# Altium schematic sheet PCIe_USB30_Power.SchDoc(PCIe_USB30_Power)
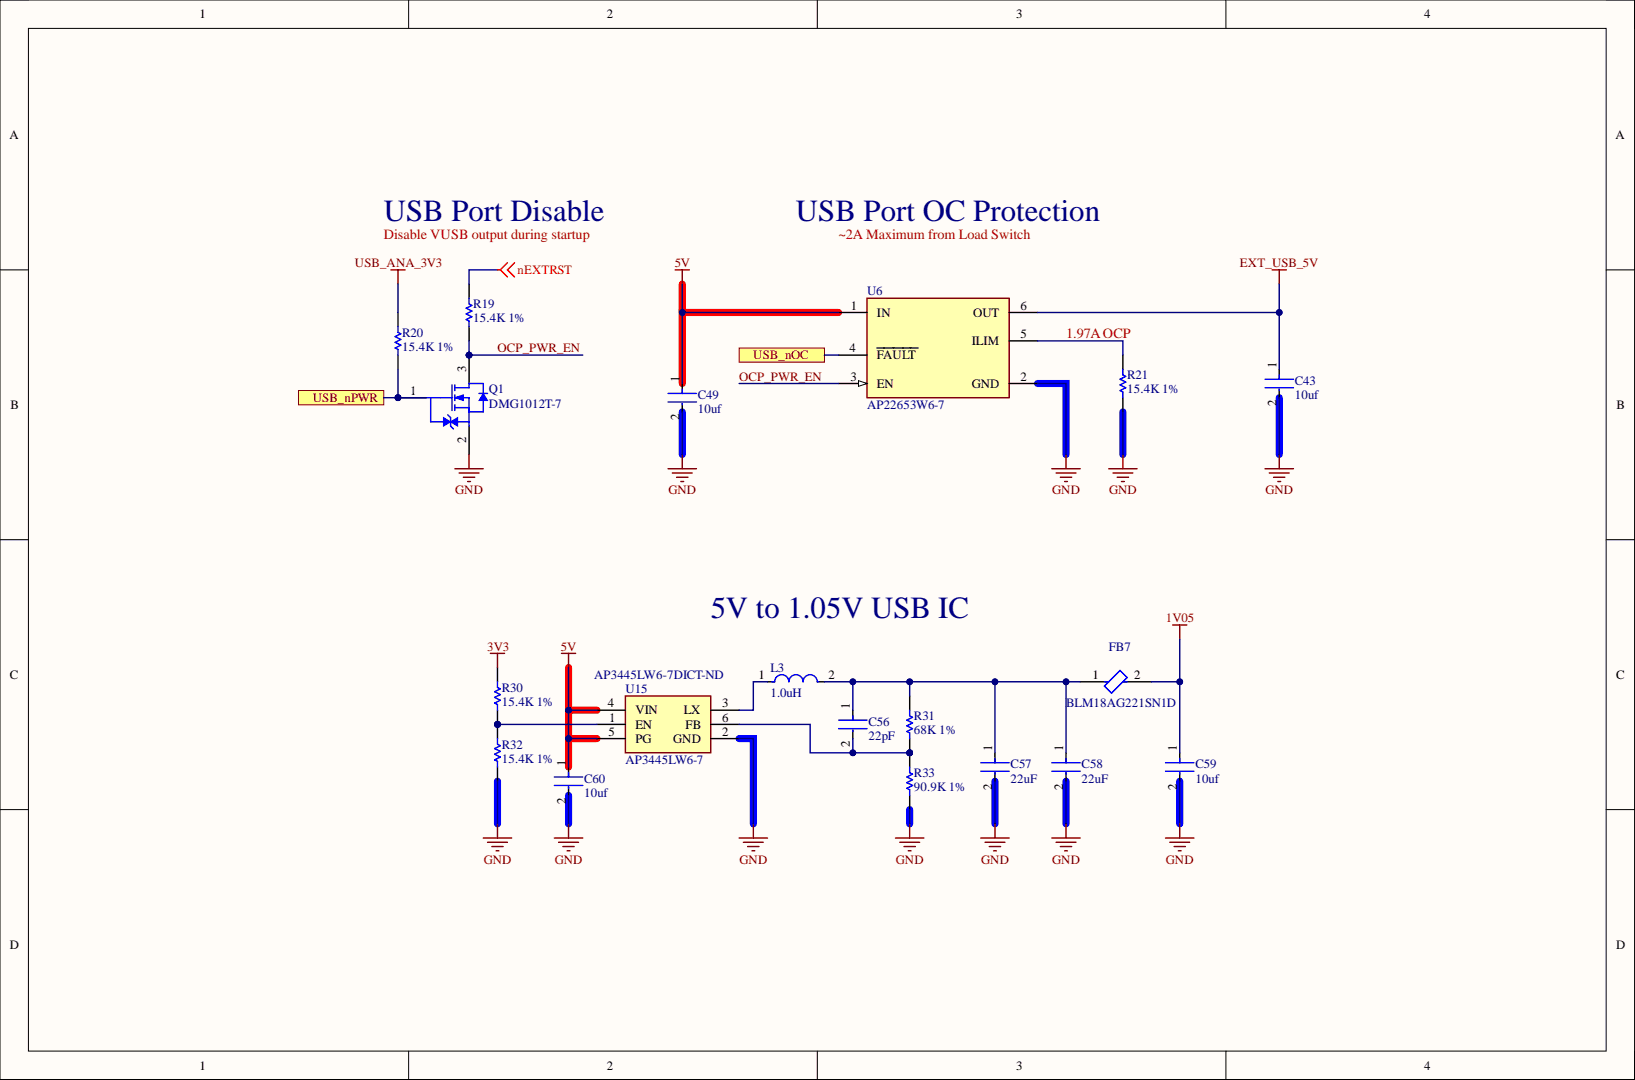
[diagram p0: PCIe_USB30_Power.SchDoc]

## Parts
C43  10uf  [FP-GRM188-0_2-IPC_C]
C49  10uf  [FP-GRM188-0_2-IPC_C]
C56  22pF  [FP-C0603C-CF-MFG]
C57  22uF  [FP-GRM21B-0_15-MFG]
C58  22uF  [FP-GRM21B-0_15-MFG]
C59  10uf  [FP-GRM188-0_2-IPC_C]
C60  10uf  [FP-GRM188-0_2-IPC_C]
FB7  BLM18AG221SN1D  [FP-BLM18-0_15-t0_8-IPC_A]
L3  1.0uH  [FP-NR4018-MFG]
Q1  DMG1012T-7  [DIOD-SOT523-3_V]
R19  15.4K 1%  [RESC1005X40X25ML05T10]
R20  15.4K 1%  [RESC1005X40X25ML05T10]
R21  15.4K 1%  [RESC1005X40X25ML05T10]
R30  15.4K 1%  [RESC1005X40X25ML05T10]
R31  68K 1%  [RESC1005X40X25ML05T05]
R32  15.4K 1%  [RESC1005X40X25ML05T10]
R33  90.9K 1%  [RESC1005X40X25NL05T10]
U6  AP22653W6-7  [FP-SOT26-MFG]
U15  AP3445LW6-7  Supplier Part Number 1: AP3445LW6-7DICT-ND  [SOT95P285X140-6N]

## Ports
USB_nOC, USB_nPWR

## Nets
1V05: C59.1 FB7.2
3V3: R30.2
5V: C49.1 C60.1 U6.1(IN) U15.4(VIN) U15.5(PG)
EXT_USB_5V: C43.1 U6.6(OUT)
GND: C43.2 C49.2 C57.2 C58.2 C59.2 C60.2 Q1.2 R21.1 R32.1 R33.1 U6.2(GND) U15.2(GND)
nEXTRST: R19.2
USB_ANA_3V3: R20.2
OCP_PWR_EN: Q1.3 R19.1 U6.3(EN)
USB_nOC: U6.4(FAULT) ; port USB_nOC
USB_nPWR: Q1.1 R20.1 ; port USB_nPWR
NetC56_1: C56.1 C57.1 C58.1 FB7.1 L3.2 R31.1
NetC56_2: C56.2 R31.2 R33.2 U15.6(FB)
NetL3_1: L3.1 U15.3(LX)
NetR21_2: R21.2 U6.5(ILIM)
NetR30_1: R30.1 R32.2 U15.1(EN)

## Library data of the parts
C43 C49 C59 C60 = CMP-06035-045466-1: Chip Multilayer Ceramic Capacitors for General Purpose, 0603, 10uF, X5R, 15%, 20%, 35V; Capacitance: 10uF; Case Code (Imperial): 0603; Case Code (Metric): 1608; Case/Package: 0603; Component Kind: Standard; Dielectric: X5R; Length: 0.063inch; Library Name: Altium Content Vault; Max Operating Temperature: 85°C; Min Operating Temperature: -55°C; Mount: Surface Mount; Packaging: Tape and Reel; Thickness: 0.039inch; Tolerance: 20%; Voltage Rating: 35V; Voltage Rating (DC): 35V; Width: 0.031inch
C56 = CMP-1035-00818-3: CAP CER 22PF 50V NP0 0603; Manufacturer: KEMET; Manufacturer Part Number: C0603C220D5GACTU; Automotive Grade: Grade 1; Material: Ceramic; Temperature Characteristic: C0G; Temperature Coefficient: 30ppm/°C; Tolerance: 0.5 pF; Automotive: Yes; Capacitance: 22 pF; Category: Cap; Component Kind: Standard; ComponentLink2Description: Datasheet; ComponentLink2URL: https://api.kemet.com/component-edge/download/datasheet/C0603C100J5GACAUTO.pdf; Device Class L1: Passive Components; Device Class L2: Capacitors; Device Class L3: Ceramic Capacitors; Digikey Description: CAP CER 22PF 50V NP0 0603; Height: 0.87mm; Lead Free: Yes; Library Name: Altium Content Vault; Mouser Description: Multilayer Ceramic Capacitors MLCC - SMD/SMT 50volts 22pF C0G 0.5%; Octopart: https://octopart.com/c0603c220d5gactu-kemet-9143734; Package: C0603C-CF; RoHS: TRUE; Temperature: -55°C to +125°C; Temperature Range High: +125°C; Temperature Range Low: -55°C; Value: 22 pF; Voltage Rating: 50 V
C57 C58 = CMP-2007-03385-2: Chip Multilayer Ceramic Capacitors for General Purpose, 0805, 22uF, X5R, 15%, 20%, 6.3V; Capacitance: 22uF; Case Code (Imperial): 0805; Case Code (Metric): 2012; Case/Package: 0805; Component Kind: Standard; Depth: 1.25mm; Dielectric: X5R; Height: 1.25mm; Lead Free: Lead Free; Length: 2mm; Library Name: Altium Content Vault; Material: Ceramic; Max Operating Temperature: 85°C; Min Operating Temperature: -55°C; Mount: Surface Mount; Package Quantity: 1; Packaging: Tape and Reel; Radiation Hardening: No; REACH SVHC: No SVHC; Termination: SMD/SMT; Thickness: 0.053inch; Tolerance: 20%; Voltage: 6.3V; Voltage Rating: 6.3V; Voltage Rating (DC): 6.3V; Width: 0.049inch
FB7 = CMP-0686-00445-3: Chip Ferrite Bead, 0603, 220Ω @ 100MHz, 0.25Ω, 25%, 700mA; Current Rating: 500mA; DC Resistance (DCR): 250mR; Impedance: 220R; Material: Steel; Number of Circuits: 1; Shielding: Unshielded; Case Code (Imperial): 0603; Case Code (Metric): 1608; Case/Package: 0603; Component Kind: Standard; Core Material: Ferrite; DC Current: 500mA; DC Resistance-Max: 250mR; Depth: 0.8mm; Frequency: 100MHz; Height: 0.8mm; Lead Free: Lead Free; Length: 1.6mm; Library Name: Altium Content Vault; Max Current Rating: 500mA; Max DC Current: 500mA; Max Operating Temperature: 125°C; Military Standard: Not; Min Operating Temperature: -55°C; Number of Lines: 1; Number of Pins: 2; Package Quantity: 1; Packaging: Tape and Reel; Radiation Hardening: No; REACH SVHC: No SVHC; RoHS Compliant: Yes; Series: BLM18A; Termination: SMD/SMT; Test Frequency: 100MHz; Tolerance: 25%; Width: 0.8mm
L3 = CMP-14484-000491-1: SMD Power Inductors for Automotive Applications 1.0uH ±30% 3.2A 32.4mΩ; Core Material: Ferrite; DC Resistance (DCR): 32.4mR; Inductance: 1uH; Saturation Current: 4A; Self Resonant Frequency: 90MHz; Shielding: Shielded; Test Frequency: 100kHz; Case/Package: Nonstandard; Component Kind: Standard; Current Rating: 3.2A; Halogen Free: Halogen Free; Height: 1.8mm; Height - Seated (Max): 0.071inch; Length: 4mm; Library Name: Altium Content Vault; Max DC Current: 3.2A; Max Operating Temperature: 125°C; Min Operating Temperature: -40°C; Mount: Surface Mount; Package Quantity: 3500; Packaging: Tape and Reel; Ratings: AEC-Q200; Resistor Type: Wirewound; RoHS Compliant: Yes; Termination: SMD/SMT; Tolerance: 30%; Weight: 0.003527oz; Width: 0.157inch
Q1 = CMP-2000-07450-1: N-Channel Enhancement Mode MOSFET, 20 V, 630 mA, -55 to 150 degC, 3-Pin SOT23, RoHS, Tape and Reel; Manufacturer: Diodes Inc; Case\/Package: SOT-523; Continuous Drain Current (ID): 630mA; Drain to Source Breakdown Voltage: 20V; Drain to Source Resistance: 300mOhm; Element Configuration: Single; Fall Time: 12.3ns; Gate to Source Voltage (Vgs): 6V; Input Capacitance: 60.67pF; Max Power Dissipation: 280mW; Number of Channels: 1; On-State Resistance: 400MOhm; Rise Time: 7.4ns; Threshold Voltage: 1V; Turn-Off Delay Time: 26.7ns; Turn-On Delay Time: 5.1ns; Component Kind: Standard; Contact Plating: Matte; Datasheet URL: https://www.diodes.com/assets/Datasheets/ds31783.pdf; Datasheet Version: Rev. 3-2, 01/2012; Drain to Source Voltage (Vdss): 20V; Height: 0.8mm; Lead Free: LeadFree; Length: 1.7mm; Library Name: Altium Content Vault; Manufacturer URL: http://www.diodes.com/; Max Operating Temperature: 150degC; Min Operating Temperature: -55degC; Mount: SurfaceMount; Mounting Technology: SurfaceMount; Number of Elements: 1; Number of Pins: 3; Package Description: 3-Pin Small Outline Transistor, Body 1.6 x 0.8 mm, Pitch 0.5 mm; Package Quantity: 3000; Package Reference: SOT523-3; Packaging: TapeandReel; Power Dissipation: 280mW; Radiation Hardening: No; Rds On Max: 400mOhm; REACH SVHC: NoSVHC; RoHSCompliant: true; Weight: 7.1E-05oz; Width: 0.85mm
R19-R21 R30 R32 = CMP-1011-00642-2: Manufacturer: Yageo; Part Number: RC0402FR-0715K4L; Component Kind: Standard; Library Name: Altium Content Vault
R31 = CMP-2002-01168-1: Manufacturer: Panasonic; Part Number: ERJ2RKF6802X; Component Kind: Standard; Library Name: Altium Content Vault
R33 = CMP-2002-07837-1: Manufacturer: Yageo; Part Number: RC0402FR-0790K9L; Component Kind: Standard; Library Name: Altium Content Vault
U6 = CMP-12749-000002-1: LOAD SWITCH,SOT26,T&R,3K; Component Kind: Standard; Library Name: Altium Content Vault
U15 = AP3445LW6-7: Integrated Circuit; Manufacturer_Name: Diodes Inc.; Arrow Part Number: AP3445LW6-7; Arrow Price/Stock: https://www.arrow.com/en/products/ap3445lw6-7/diodes-incorporated; Component Kind: Standard; Datasheet Link: https://www.mouser.com/datasheet/2/115/AP3445-L-1382378.pdf; Height: 1.4mm; Manufacturer_Part_Number: AP3445LW6-7; Mouser Part Number: 621-AP3445LW6-7; Mouser Price/Stock: https://www.mouser.co.uk/ProductDetail/Diodes-Incorporated/AP3445LW6-7?qs=%252BEew9%252B0nqrAxy08hG7uGeQ%3D%3D; Supplier 1: Digi-Key
